# Altium schematic sheet GloveGlow.SchDoc
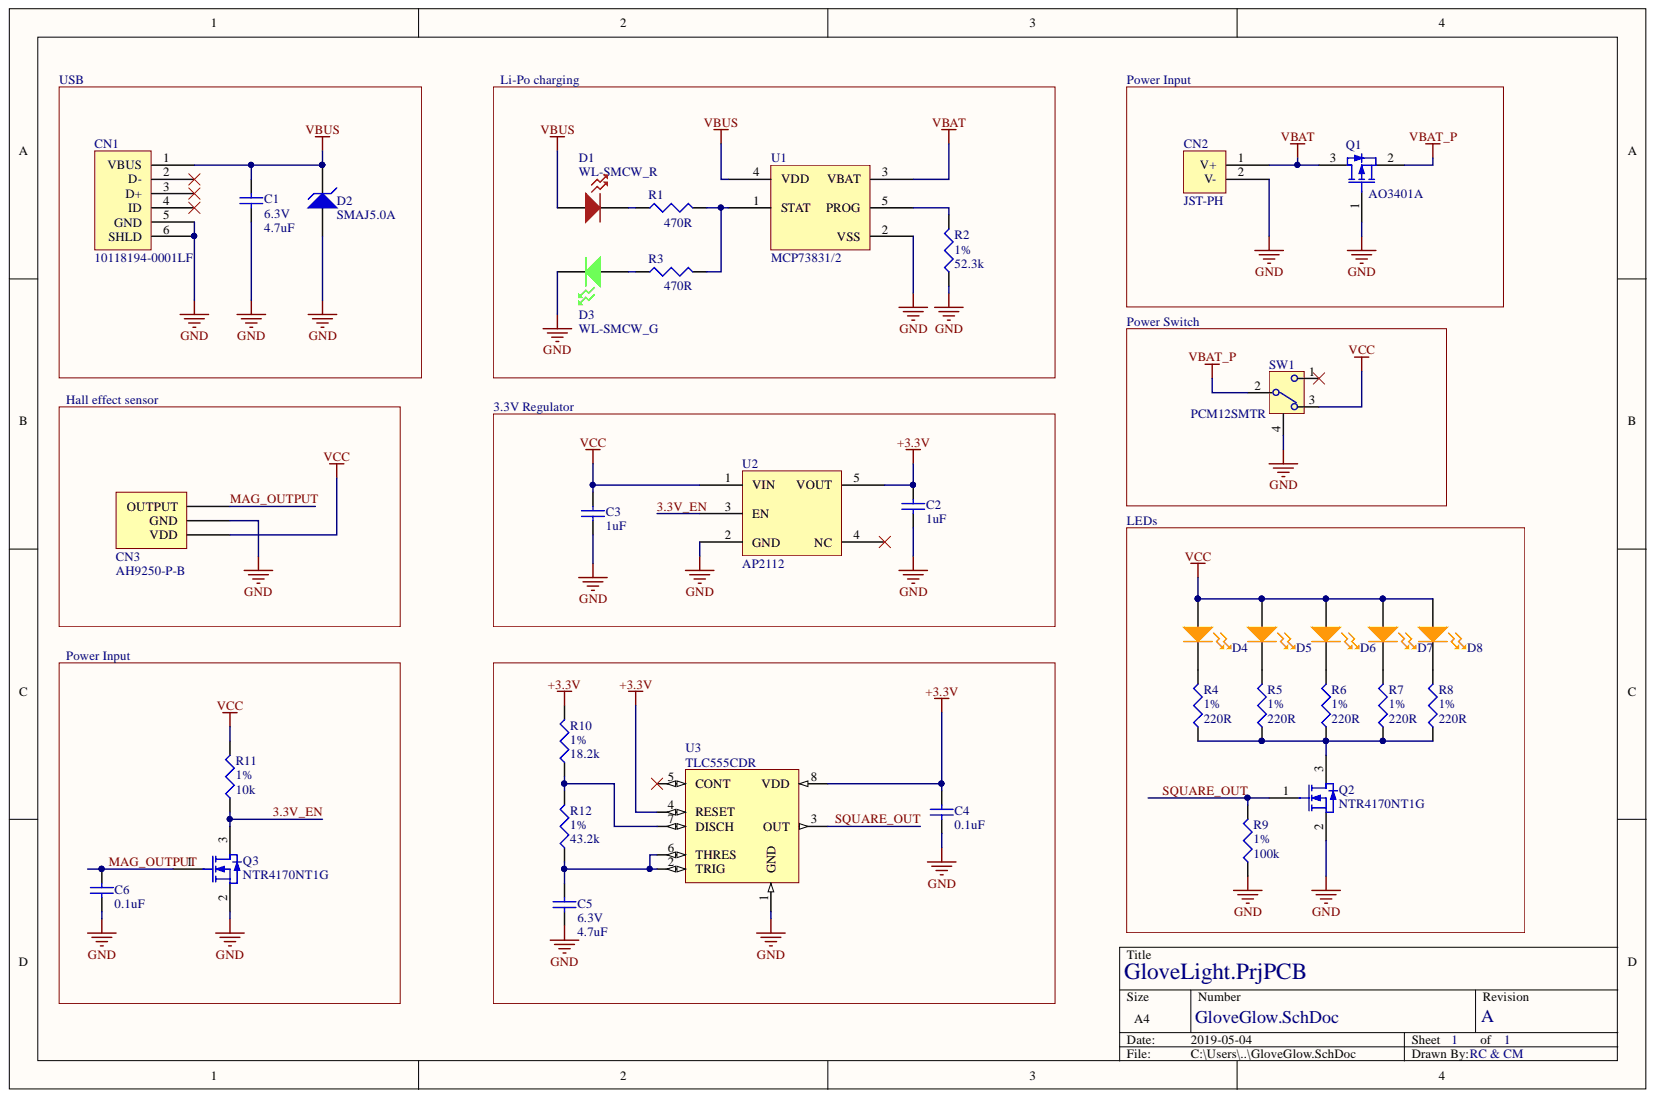
[diagram p0: GloveGlow.SchDoc]

## Parts
C1  value 4.7uF  Voltage Rating: 6.3V  [TMK107B7105KA-T]  (hidden comment: CAP CER 4.7uF 10% 25V X7R 0603)
C2  value 1uF  [TMK107B7105KA-T]  (hidden comment: CAP CER 1uF 10% 25V X7R 0603)
C3  value 1uF  [TMK107B7105KA-T]  (hidden comment: CAP CER 1uF 10% 25V X7R 0603)
C4  value 0.1uF  [CAP CER 0.1UF 16V X7R 0603]  (hidden comment: CAP CER 0.1uF 10% 10V X7R 0603_1)
C5  value 4.7uF  Voltage Rating: 6.3V  [TMK107B7105KA-T]  (hidden comment: CAP CER 4.7uF 10% 25V X7R 0603)
C6  value 0.1uF  [CAP CER 0.1UF 16V X7R 0603]  (hidden comment: CAP CER 0.1uF 10% 10V X7R 0603_1)
CN1  USB MICRO B  [10118194-0001LF]  (value not drawn)
CN2  MPN: JST-PH  [JST-PH 2]  (hidden comment: JSP-PH-2)
CN3  CONN_3PIN  [AH9250]  (value not drawn)
D1  Manufacturer Part Number: WL-SMCW_R  [WL-SMCW - RED]  (hidden comment: WL-SMCW_RED)
D2  SMAJ5.0A  [SMAJ]
D3  Manufacturer Part Number: WL-SMCW_G  [WL-SMCW]  (hidden comment: WL-SMCW_GREEN)
D4  LA A676-R1S2-1-Z  [LA A676-R1S2-1-Z]  (value not drawn)
D5  LA A676-R1S2-1-Z  [LA A676-R1S2-1-Z]  (value not drawn)
D6  LA A676-R1S2-1-Z  [LA A676-R1S2-1-Z]  (value not drawn)
D7  LA A676-R1S2-1-Z  [LA A676-R1S2-1-Z]  (value not drawn)
D8  LA A676-R1S2-1-Z  [LA A676-R1S2-1-Z]  (value not drawn)
Q1  AO3401A  [AO3401A]
Q2  NTR4170NT1G  [NTR5103N]
Q3  NTR4170NT1G  [NTR5103N]
R1  value 470R  [RES_0603_YAGEO]  (hidden comment: RES 470R 1% 1/10W 0603)
R2  value 52.3k  Tolerance: 1%  [RES_0603_YAGEO]  (hidden comment: RES 10K 1% 1/10W 0603)
R3  value 470R  [RES_0603_YAGEO]  (hidden comment: RES 470R 1% 1/10W 0603)
R4  value 220R  Tolerance: 1%  [RES_0603_YAGEO]  (hidden comment: RES 220R 1% 1/10W 0603)
R5  value 220R  Tolerance: 1%  [RES_0603_YAGEO]  (hidden comment: RES 220R 1% 1/10W 0603)
R6  value 220R  Tolerance: 1%  [RES_0603_YAGEO]  (hidden comment: RES 220R 1% 1/10W 0603)
R7  value 220R  Tolerance: 1%  [RES_0603_YAGEO]  (hidden comment: RES 220R 1% 1/10W 0603)
R8  value 220R  Tolerance: 1%  [RES_0603_YAGEO]  (hidden comment: RES 220R 1% 1/10W 0603)
R9  value 100k  Tolerance: 1%  [RES_0603_YAGEO]  (hidden comment: RES 100K 1% 1/10W 0603)
R10  value 18.2k  Tolerance: 1%  [RES_0603_YAGEO]  (hidden comment: RES 18.2K OHM 1% 1/10W 0603)
R11  value 10k  Tolerance: 1%  [RES_0603_YAGEO]  (hidden comment: RES 10K 1% 1/10W 0603)
R12  value 43.2k  Tolerance: 1%  [RES_0603_YAGEO]  (hidden comment: RES 43.1K 1% 1/10W 0603)
SW1  PCM12SMTR  [PCM12SMTR]
U1  MCP738  Manufacturer Part Number: MCP73831/2  [MCP73811/2]
U2  AP2112  [AP2112 SOT25]
U3  TLC555  Manufacturer Part Number: TLC555CDR  [TLC555]

## Nets
+3.3V: C2.1 C4.2 R10.2 U2.5(VOUT) U3.4(RESET) U3.8(VDD)
GND: C1.1 C2.2 C3.2 C4.1 C5.1 C6.1 CN1.5(GND) CN1.6(SHLD) CN2.2 CN3.2 D2.1 D3.2 Q1.1 Q2.2 Q3.2 R2.1 R9.1 SW1.4 U1.2(VSS) U2.2(GND) U3.1(GND)
VBAT: CN2.1 Q1.3 U1.3(VBAT)
VBAT_P: Q1.2 SW1.2
VBUS: C1.2 CN1.1(VBUS) D1.1 D2.2 U1.4(VDD)
VCC: C3.1 CN3.1 D4.1 D5.1 D6.1 D7.1 D8.1 R11.2 SW1.3 U2.1(VIN)
3.3V_EN: Q3.3 R11.1 U2.3(EN)
MAG_OUTPUT: C6.2 CN3.3 Q3.1
SQUARE_OUT: Q2.1 R9.2 U3.3(OUT)
NetC5_2: C5.2 R12.1 U3.2(TRIG) U3.6(THRES)
NetD1_2: D1.2 R1.1
NetD3_1: D3.1 R3.1
NetD4_2: D4.2 R4.2
NetD5_2: D5.2 R5.2
NetD6_2: D6.2 R6.2
NetD7_2: D7.2 R7.2
NetD8_2: D8.2 R8.2
NetQ2_3: Q2.3 R4.1 R5.1 R6.1 R7.1 R8.1
NetR1_2: R1.2 R3.2 U1.1(STAT)
NetR2_2: R2.2 U1.5(PROG)
NetR10_1: R10.1 R12.2 U3.7(DISCH)

Not connected: CN1 2(D-) 3(D+) 4(ID); SW1 1; U2 4(NC); U3 5(CONT)

## Library data of the parts
C1 C5 = CAP CER 4.7uF 10% 25V X7R 0603: CAP CER 1uF 10% 25V X7R 0603; Component Kind: Standard; ComponentLink1Description: Datasheet; ComponentLink1URL: http://ds.yuden.co.jp/TYCOMPAS/ut/detail.do?productNo=JMK107BB7475KA-T&fileName=JMK107BB7475KA-T_SS&mode=specSheetDownload; ComponentLink2Description: Digikey; ComponentLink2URL: https://www.digikey.ca/product-detail/en/taiyo-yuden/JMK107BB7475KA-T/587-5870-1-ND/7674990; Library Name: Capacitors.IntLib; Package: 0603; Temp Coefficient: X7R; Tolerance: 10%
C4 C6 = CAP CER 0.1uF 10% 10V X7R 0603_1: CAP CER 0.1uF 10% 16V X7R 0603; Component Kind: Standard; ComponentLink1Description: Datasheet; ComponentLink1URL: http://search.murata.co.jp/Ceramy/image/img/A01X/G101/ENG/GRM188R71C104KA01-01.pdf; ComponentLink2Description: Digikey; ComponentLink2URL: https://www.digikey.ca/product-detail/en/murata-electronics-north-america/GRM188R71C104KA01D/490-1532-1-ND/587771; Library Name: Capacitors.IntLib; Package: 0603; Temp Coefficient: X7R; Tolerance: 10%; Voltage Rating: 16V
CN1 = USB MICRO B: CONN USB MICRO B RECPT SMT R/A; Component Kind: Standard; ComponentLink1Description: Datasheet; ComponentLink1URL: https://cdn.amphenol-icc.com/media/wysiwyg/files/drawing/10118194.pdf; ComponentLink2Description: Digikey; ComponentLink2URL: https://www.digikey.ca/product-detail/en/amphenol-fci/10118194-0001LF/609-4618-1-ND/2785382; Connector Type: USB MICRO B; Library Name: Connectors.IntLib; MPN: 10118194-0001LF
CN2 = JSP-PH-2: CONN HEADER PH SIDE 2POS 2MM SMD; Connector Type: JST-PH-2; Component Kind: Standard; ComponentLink1Description: Datasheet; ComponentLink1URL: http://www.jst-mfg.com/product/pdf/eng/ePH.pdf; ComponentLink2Description: Digikey; ComponentLink2URL: https://www.digikey.ca/product-detail/en/jst-sales-america-inc/S2B-PH-SM4-TB-LF-SN/455-1749-1-ND/926846; Library Name: Connectors.IntLib
CN3 = AH9250: Component Kind: Standard; ComponentLink1Description: Datasheet; ComponentLink1URL: https://www.diodes.com/assets/Datasheets/AH9250.pdf; ComponentLink2Description: Digikey; ComponentLink2URL: https://www.digikey.ca/product-detail/en/diodes-incorporated/AH9250-P-B/AH9250-P-BDI-ND/5592452; Description: CONN_3PIN; Library Name: Connectors.IntLib
D1 = WL-SMCW_RED: Diode LED RED 20mA 0603; Forward Voltage: 3.2V; Component Kind: Standard; ComponentLink1Description: Datasheet; ComponentLink1URL: http://katalog.we-online.de/led/datasheet/150060RS75000.pdf; ComponentLink2Description: Digikey; ComponentLink2URL: https://www.digikey.com/product-detail/en/wurth-electronics-inc/150060RS75000/732-4978-1-ND/4489899; Library Name: Diodes.IntLib; Package: 0603
D2 = SMAJ5.0A: TVS DIODE 5V 9.2V SMA; Standoff voltage: 6.4V; Component Kind: Standard; ComponentLink1Description: Datasheet; ComponentLink1URL: https://www.diodes.com/assets/Datasheets/ds19005.pdf; ComponentLink2Description: Digikey; ComponentLink2URL: https://www.digikey.ca/product-detail/en/diodes-incorporated/SMAJ5.0A-13-F/SMAJ5.0A-FDICT-ND/816522; Library Name: Diodes.IntLib; Manufactuer Part Number: SMAJ5.0A; Package: SMA
D3 = WL-SMCW_GREEN: Diode LED Green 20mA 0603; Forward Voltage: 3.2V; Component Kind: Standard; ComponentLink1Description: Datasheet; ComponentLink1URL: http://katalog.we-online.de/led/datasheet/150060GS75000.pdf; ComponentLink2Description: Digikey; ComponentLink2URL: https://www.digikey.com/product-detail/en/wurth-electronics-inc/150060GS75000/732-4971-1-ND/4489896; Library Name: Diodes.IntLib; Package: 0603
D4-D8 = LA A676-R1S2-1-Z: LED AMBER CLEAR SMD R/A; Forward Voltage: 2.0V; Component Kind: Standard; ComponentLink1Description: Datasheet; ComponentLink1URL: http://www.kingbrightusa.com/images/catalog/SPEC/APA1606SECK.pdf; ComponentLink2Description: Digikey; ComponentLink2URL: https://www.digikey.ca/product-detail/en/kingbright/APA1606SECK/754-1053-1-ND/1747770; Library Name: Diodes.IntLib; Manufacturer Part Number: LA A676-R1S2-1-Z; Package: 2-SMD, Boomerang
Q1 = AO3401A: MOSFET P-CH 30V 4A SOT23; Vgs On: 2.5 to 10V; Component Kind: Standard; ComponentLink1Description: Datasheet; ComponentLink1URL: http://aosmd.com/res/data_sheets/AO3401A.pdf; ComponentLink2Description: Digikey; ComponentLink2URL: https://www.digikey.com/product-detail/en/alpha-omega-semiconductor-inc/AO3401A/785-1001-1-ND/1855943; Current Rating: 4A; Library Name: Transistors.IntLib; Manufacturer Part Number: AO3401A; Package: SOT-23-3L; Type: P-Ch; Vdss Max: 30V
Q2 Q3 = NTR4170NT1G: MOSFET N-CH 30V 3.2A SOT23; Vgs On: 2.5 to 10V; Component Kind: Standard; ComponentLink1Description: Datasheet; ComponentLink1URL: http://www.onsemi.com/pub/Collateral/NTR4170N-D.PDF; ComponentLink2Description: Digikey; ComponentLink2URL: https://www.digikey.ca/product-detail/en/on-semiconductor/NTR4170NT1G/NTR4170NT1GOSCT-ND/2623483; Current Rating: 3.2A; Library Name: Transistors.IntLib; Manufacturer Part Number: NTR4170NT1G; Package: SOT-23-3L; Type: N-Ch; Vdss Max: 30V
R10 = RES 18.2K OHM 1% 1/10W 0603: RES SMD 18.2K OHM 1% 1/10W 0603; Component Kind: Standard; ComponentLink1Description: Datasheet; ComponentLink1URL: http://www.yageo.com/documents/recent/PYu-RC_Group_51_RoHS_L_8.pdf; ComponentLink2Description: Digikey; ComponentLink2URL: https://www.digikey.ca/product-detail/en/yageo/RC0603FR-0718K2L/311-18.2KHRCT-ND/729938; Library Name: Resistors.IntLib; Power Rating: 1/10W; Size: 0603
SW1 = PCM12SMTR: SWITCH SLIDE SPDT 300MA 6V; Current Rating: 0.3A; Component Kind: Standard; ComponentLink1Description: Datasheet; ComponentLink1URL: https://www.ckswitches.com/media/1424/pcm.pdf; ComponentLink2Description: Digikey; ComponentLink2URL: https://www.digikey.ca/product-detail/en/c-k/PCM12SMTR/401-2016-1-ND/1640125; Library Name: MISC.IntLib; MPN: PCM12SMTR; Voltage Rating: 6V
U1 = MCP738: IC LI-ION/LI-POLY CTRLR SOT23-5; Component Kind: Standard; ComponentLink1Description: Datasheet; ComponentLink1URL: http://ww1.microchip.com/downloads/en/DeviceDoc/20001984g.pdf; ComponentLink2Description: Digikey; ComponentLink2URL: https://www.digikey.ca/product-detail/en/microchip-technology/MCP73831T-2ACI-OT/MCP73831T-2ACI-OTCT-ND/1979802; Library Name: ICs.IntLib; Package: 5-SOT-23
U2 = AP2112: IC REG LINEAR 3.3V 600MA SOT25; Component Kind: Standard; ComponentLink1Description: Datasheet; ComponentLink1URL: https://www.diodes.com/assets/Datasheets/AP2112.pdf; ComponentLink2Description: Digikey; ComponentLink2URL: https://www.digikey.ca/product-detail/en/diodes-incorporated/AP2112K-3.3TRG1/AP2112K-3.3TRG1DICT-ND/4505257; Library Name: ICs.IntLib; Manufacturer Part Number: AP2112; Package: SOT25
U3 = TLC555: IC OSC SGL TIMER 2.1MHZ 8-SOIC; Component Kind: Standard; ComponentLink1Description: Datasheet; ComponentLink1URL: http://www.ti.com/lit/ds/symlink/tlc555.pdf; ComponentLink2Description: Digikey; ComponentLink2URL: https://www.digikey.ca/product-detail/en/texas-instruments/TLC555CDR/296-1336-1-ND/404959; Library Name: ICs.IntLib; Package: 8-SOIC
C2 C3 = CAP CER 1uF 10% 25V X7R 0603: Component Kind: Standard; ComponentLink1Description: Datasheet; ComponentLink1URL: http://ds.yuden.co.jp/TYCOMPAS/ut/detail.do?productNo=TMK107B7105KA-T&fileName=TMK107B7105KA-T_SS&mode=specSheetDownload; ComponentLink2Description: Digikey; ComponentLink2URL: https://www.digikey.ca/products/en?keywords=1276-1942-1-ND; Description: CAP CER 1uF 10% 25V X7R 0603; Library Name: Capacitors.IntLib; Package: 0603; Temp Coefficient: X7R; Tolerance: 10%; Voltage Rating: 25V
R1 R3 = RES 470R 1% 1/10W 0603: Component Kind: Standard; ComponentLink1Description: Datasheet; ComponentLink1URL: http://www.yageo.com/documents/recent/PYu-RC_Group_51_RoHS_L_8.pdf; ComponentLink2Description: Digikey; ComponentLink2URL: https://www.digikey.ca/product-detail/en/yageo/RC0603FR-07470RL/311-470HRCT-ND/730203; Description: RES 470R 1% 1/10W 0603; Library Name: Resistors.IntLib; Power Rating: 1/10W; Size: 0603; Tolerance: 1%
R2 R11 = RES 10K 1% 1/10W 0603: Component Kind: Standard; ComponentLink1Description: Datasheet; ComponentLink1URL: http://www.yageo.com/documents/recent/PYu-RC_Group_51_RoHS_L_8.pdf; ComponentLink2Description: Digikey; ComponentLink2URL: https://www.digikey.ca/product-detail/en/yageo/RC0603FR-0710KL/311-10.0KHRCT-ND/729827; Description: RES 10K 1% 1/10W 0603; Library Name: Resistors.IntLib; Power Rating: 1/10W; Size: 0603
R4-R8 = RES 220R 1% 1/10W 0603: Component Kind: Standard; ComponentLink1Description: Datasheet; ComponentLink1URL: http://www.yageo.com/documents/recent/PYu-RC_Group_51_RoHS_L_8.pdf; ComponentLink2Description: Digikey; ComponentLink2URL: https://www.digikey.com/product-detail/en/yageo/RC0603FR-07220RL/311-220HRCT-ND/730008; Description: RES 220R 1% 1/10W 0603; Library Name: Resistors.IntLib; Power Rating: 1/10W; Size: 0603
R9 = RES 100K 1% 1/10W 0603: Component Kind: Standard; ComponentLink1Description: Datasheet; ComponentLink1URL: http://www.yageo.com/documents/recent/PYu-RC_Group_51_RoHS_L_8.pdf; ComponentLink2Description: Digikey; ComponentLink2URL: https://www.digikey.ca/product-detail/en/yageo/RC0603FR-07100KL/311-100KHRDKR-ND/732783; Description: RES 100K 1% 1/10W 0603; Library Name: Resistors.IntLib; Power Rating: 1/10W; Size: 0603
R12 = RES 43.1K 1% 1/10W 0603: Component Kind: Standard; ComponentLink1Description: Datasheet; ComponentLink1URL: http://www.yageo.com/documents/recent/PYu-RC_Group_51_RoHS_L_8.pdf; ComponentLink2Description: Digikey; ComponentLink2URL: https://www.digikey.ca/product-detail/en/yageo/RC0603FR-0743K2L/311-43.2KHRCT-ND/730182; Description: RES 43.1K 1% 1/10W 0603; Library Name: Resistors.IntLib; Power Rating: 1/10W; Size: 0603
